# Altium schematic sheet BBB-Supply.SchDoc(BBB-Supply)
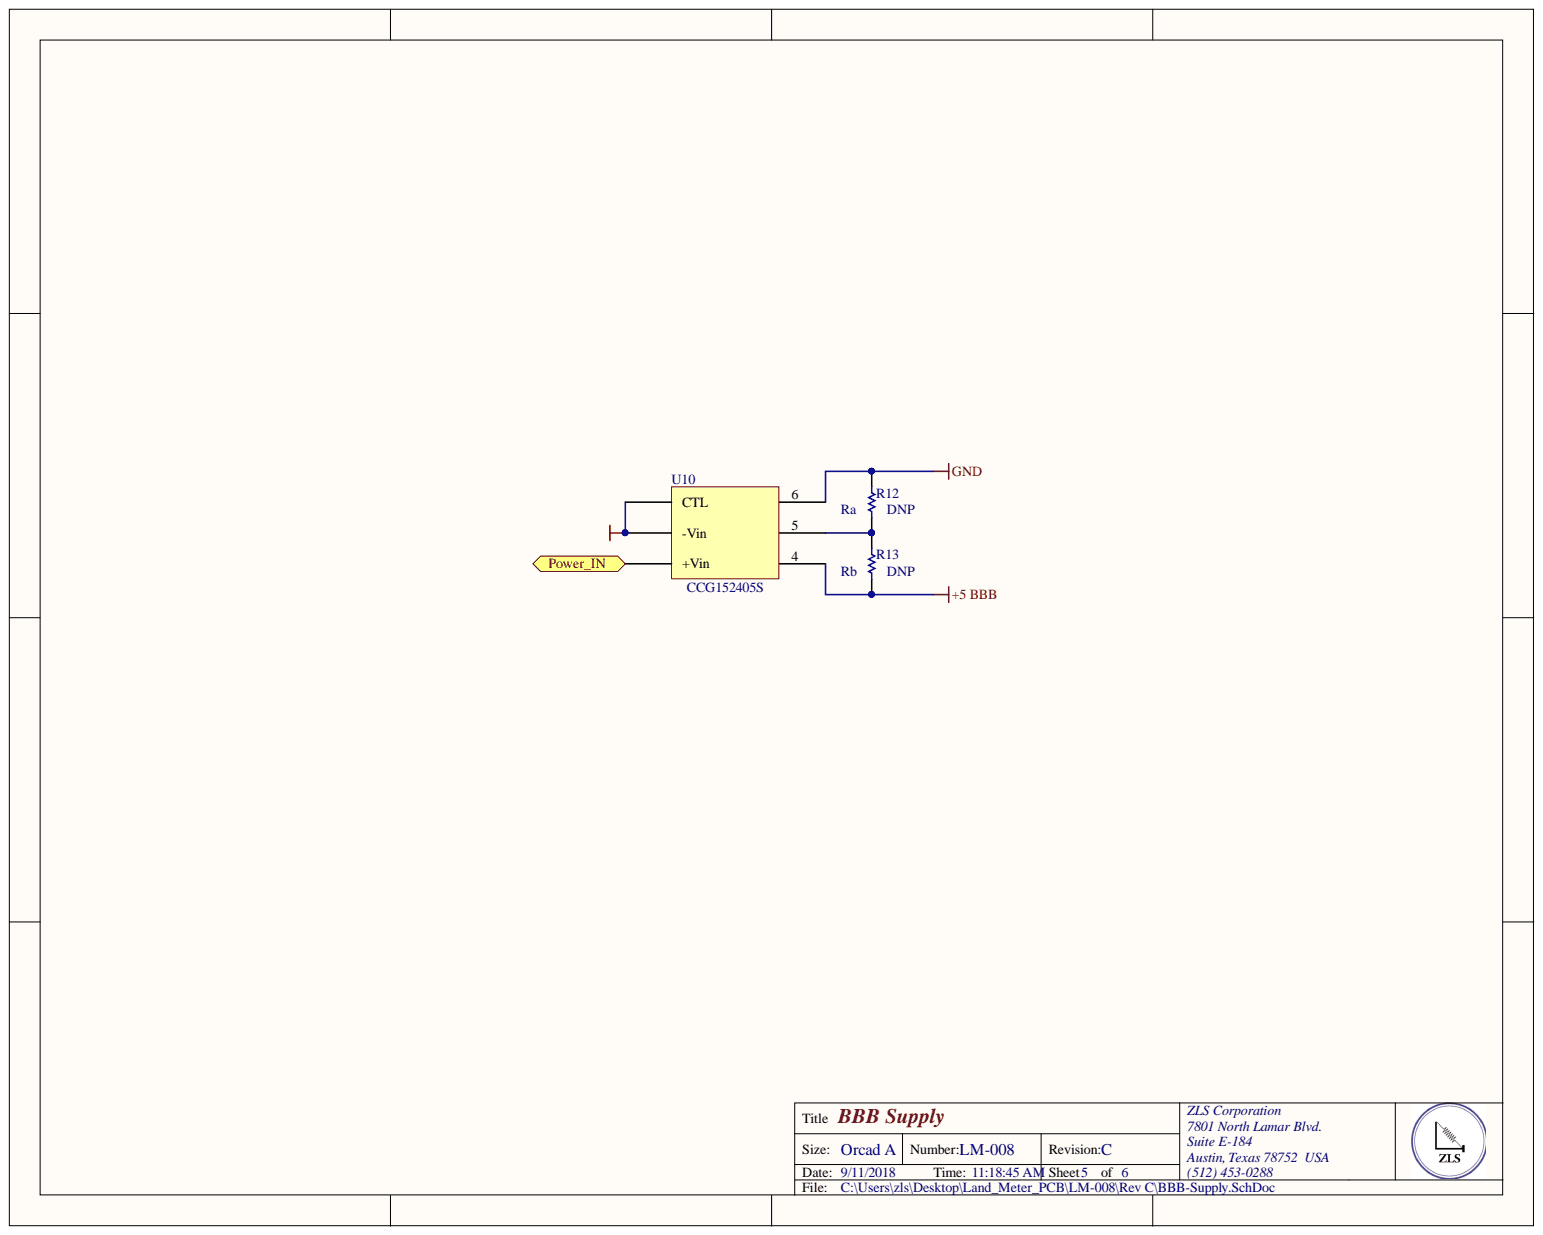
[diagram p0: BBB-Supply.SchDoc]

## Parts
R12  DNP  [RESC0805(2012)_M]
R13  DNP  [RESC0805(2012)_M]
U10  CCG152405S  [PXB15-24WS05/NT]

## Ports
Power_IN

## Nets
+5 BBB: R13.1 U10.4
GND: R12.2 U10.1(CTL) U10.2(-Vin) U10.6
Power_IN: U10.3(+Vin) ; port Power_IN
NetR12_1: R12.1 R13.2 U10.5

## Library data of the parts
R12 = CMP-1013-00017-1: Vout = 12.05 ­ (445.7 / 63.1+Ra); Library Name: Altium Content Vault; Component Kind: Standard
R13 = CMP-1013-00017-1: Vout = 12.05 + (50.96 / 22+Rb); Library Name: Altium Content Vault; Component Kind: Standard
U10 = PXB15-24WS05/NT: DC DC CONVERTER 5V 15W; Manufacturer: TDK-Lambda Americas Inc.; Voltage - Input (Min): 9V; Voltage - Input (Max): 36V; Efficiency: 87%; Current - Output (Max): 3A; Control Features: Enable, Active Low; Voltage - Output 3: -; Voltage - Output 2: -; Voltage - Output 1: 5V; Type: Isolated Module; Supplier Part Number 1: 285-2605-ND; Supplier 1: Digi-Key; Stock 1: 154; Size / Dimension: 1.00" L x 1.00" W x 0.39" H (25.4mm x 25.4mm x 9.9mm); RoHS: RoHS Compliant; Pricing 1: 1=28.5, 10=27.75 (USD); Part Status: Active; Packaging: Tube; Package / Case: 6-DIP Module; Operating Temperature: -40°C ~ 85°C; Mounting Type: Through Hole; Manufacturer Part Number: CCG152405S; Features: Remote On/Off, OCP, OVP; ComponentLink1URL: http://www.us.tdk-lambda.com/ftp/specs/ccg15.pdf; ComponentLink1Description: http://www.us.tdk-lambda.com/ftp/specs/ccg15.pdf; Component Kind: Standard; Category: Power Supplies - Board Mount; Applications: ITE (Commercial); Library Name: ZLS_Schematic_lib.SchLib
